# Altium schematic sheet Sheet1.SchDoc(Sheet1)
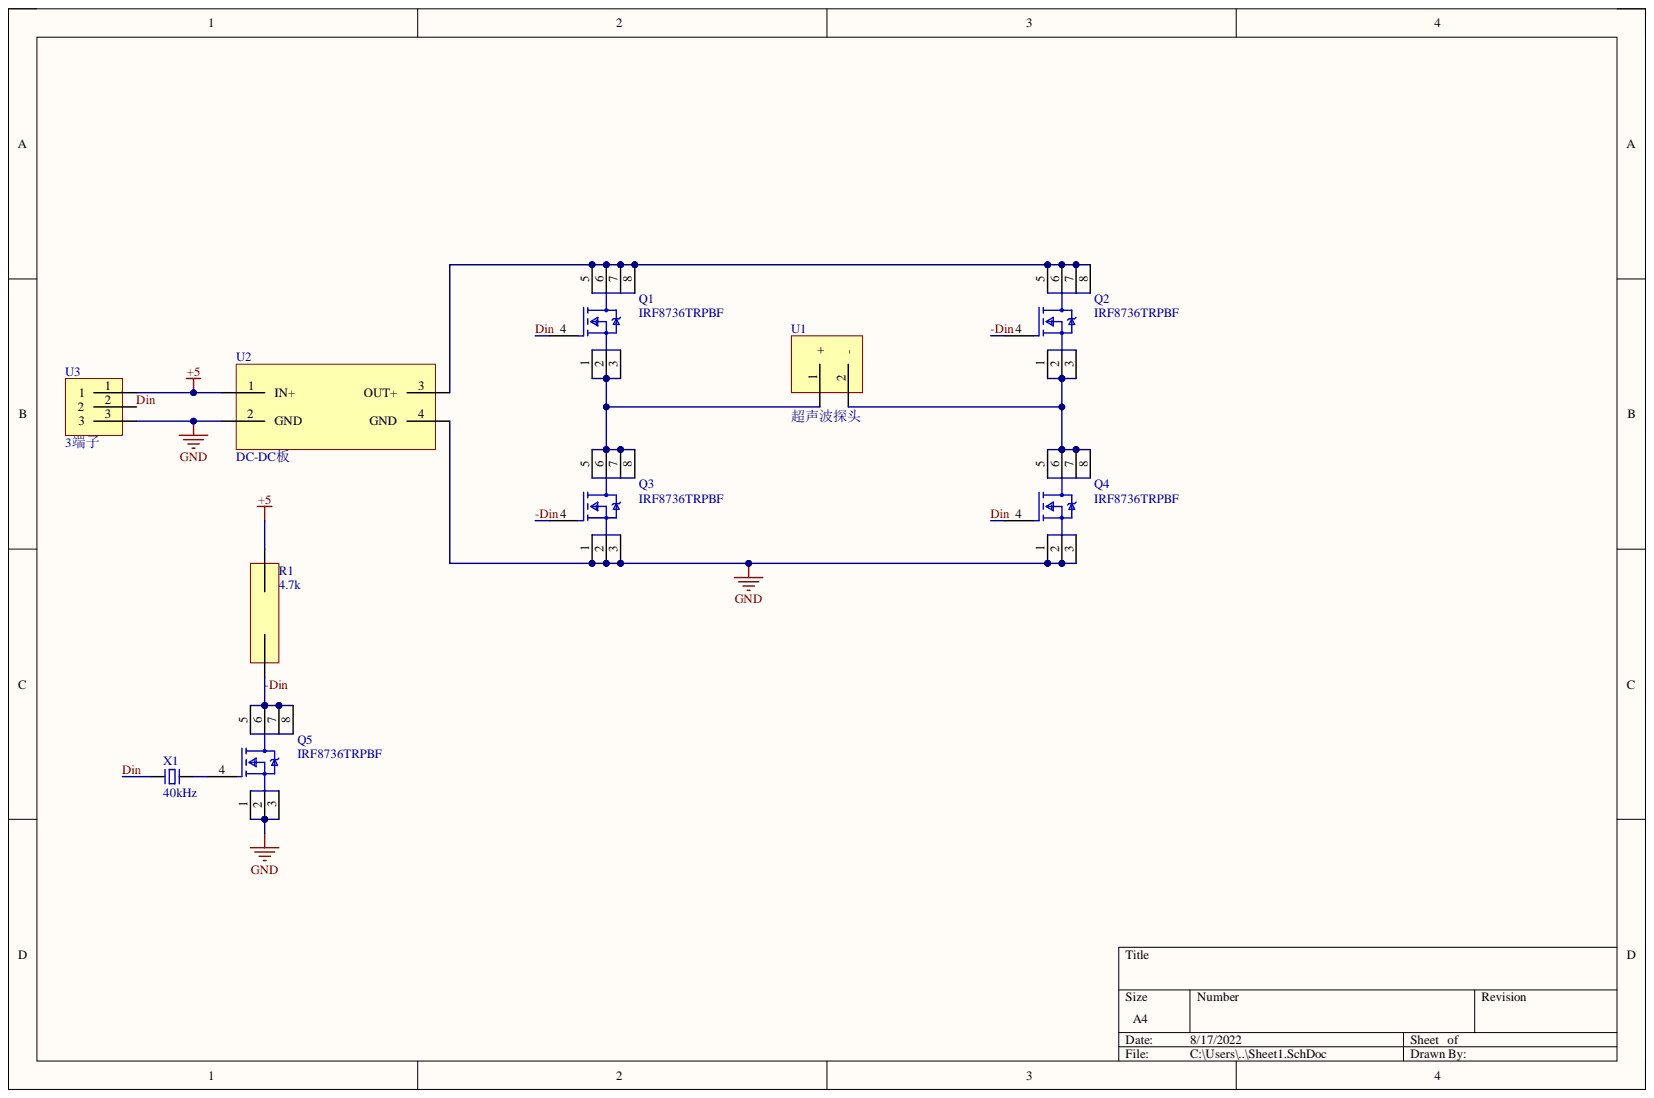
[diagram p0: Sheet1.SchDoc]

## Parts
Q1  IRF8736TRPBF  [FP-PG-DSO-8-MFG]
Q2  IRF8736TRPBF  [FP-PG-DSO-8-MFG]
Q3  IRF8736TRPBF  [FP-PG-DSO-8-MFG]
Q4  IRF8736TRPBF  [FP-PG-DSO-8-MFG]
Q5  IRF8736TRPBF  [FP-PG-DSO-8-MFG]
R1  4.7k  [0603]
U1  超声波探头  [超声波探头]
U2  DC-DC板  [DC-DC板]
U3  3端子  [HDR2.54-LI-3P]  (value not drawn)
X1  40kHz  [OSC-3x8-LI]

## Nets
+5: R1.2 U2.1(IN+) U3.1
GND: Q3.1 Q3.2 Q3.3 Q4.1 Q4.2 Q4.3 Q5.1 Q5.2 Q5.3 U2.2(GND) U2.4(GND) U3.3
-Din: Q2.4 Q3.4 Q5.5 Q5.6 Q5.7 Q5.8 R1.1
Din: Q1.4 Q4.4 U3.2 X1.2
NetQ1_1: Q1.1 Q1.2 Q1.3 Q3.5 Q3.6 Q3.7 Q3.8 U1.1
NetQ1_5: Q1.5 Q1.6 Q1.7 Q1.8 Q2.5 Q2.6 Q2.7 Q2.8 U2.3(OUT+)
NetQ2_1: Q2.1 Q2.2 Q2.3 Q4.5 Q4.6 Q4.7 Q4.8 U1.2
NetQ5_4: Q5.4 X1.1

## Library data of the parts
Q1-Q5 = CMP-32901-000123-1: MOSFET N-CH 30V 18A 8-SOIC; Manufacturer: Infineon; Depletion Mode: False; Drain To Source Resistance: 5.5mΩ; Gate Charge At Vgs: 17nC @ 4.5V; Gate To Source Voltage: 20V; Input Capacitace At Vds: 2315pF @ 15V; Max Forward Diode Voltage: 1V; Max Junction Temp: +150°C; Number Of Channels: 1; Power Dissipation: 2.5W; Pulse Drain Current: 144A; Reverse Recovery Charge: 19nC; Reverse Recovery Time: 16ns; Rthja Max: 50°C/W; Standoff Height: 0.1mm; Threshold Vgs Max: 2.35V; Threshold Vgs Min: 1.35V; Turn Off Delay Time: 13ns; Turn On Delay Time: 12ns; Automotive: No; Category: Trans; Component Kind: Standard; ComponentLink2Description: Datasheet; ComponentLink2URL: https://www.infineon.com/dgdl/irf8736pbf.pdf?fileId=5546d462533600a40153560d9e661d7f; Continuous Drain Current: 18A; Device Class L1: Discrete Semiconductors; Device Class L2: Transistors; Device Class L3: MOSFETs; Digikey Description: MOSFET N-CH 30V 18A 8-SOIC; Drain To Source Breakdown Voltage: 30V; Drain To Source Voltage: 30V; Footprint Url: https://www.infineon.com/dgdl/po-so8.pdf?fileId=5546d462580663ef0158068a1275014d; Height: 1.75mm; Ipc Land Pattern Name: SOIC127P600X155-8; Lead Free: Yes; Library Name: Altium Content Vault; Manufacturer Part Number: IRF8736TRPBF; Number Of N Channels: 1; Octopart: https://octopart.com/irf8736trpbf-infineon-65873731; Package: PG-DSO-8; RoHS: TRUE; Temperature: -55°C to +150°C; Temperature Range High: +150°C; Temperature Range Low: -55°C
R1 = 0603: Component Kind: Standard; Library Name: Schlib1.SchLib
X1 = XTAL-2P: 2脚无源脚晶振; 版权归属: 封装库来自淘宝卖家 ID：黄晶宝石; BOM名称: 2脚无源脚晶振; Component Kind: Standard; Library Name: 2.0 - 保险丝-晶振-光耦.SchLib; 学习资源: https://shop463601444.taobao.com
U1 = 超声波探头: Component Kind: Standard; Library Name: Schlib1.SchLib
U2 = DC-DC板: Component Kind: Standard; Library Name: Schlib1.SchLib
U3 = 3端子: Component Kind: Standard; Library Name: Schlib1.SchLib
